# Altium schematic sheet [10]-MODEM_CONNECTORS.SchDoc([10]-MODEM_CONNECTORS)
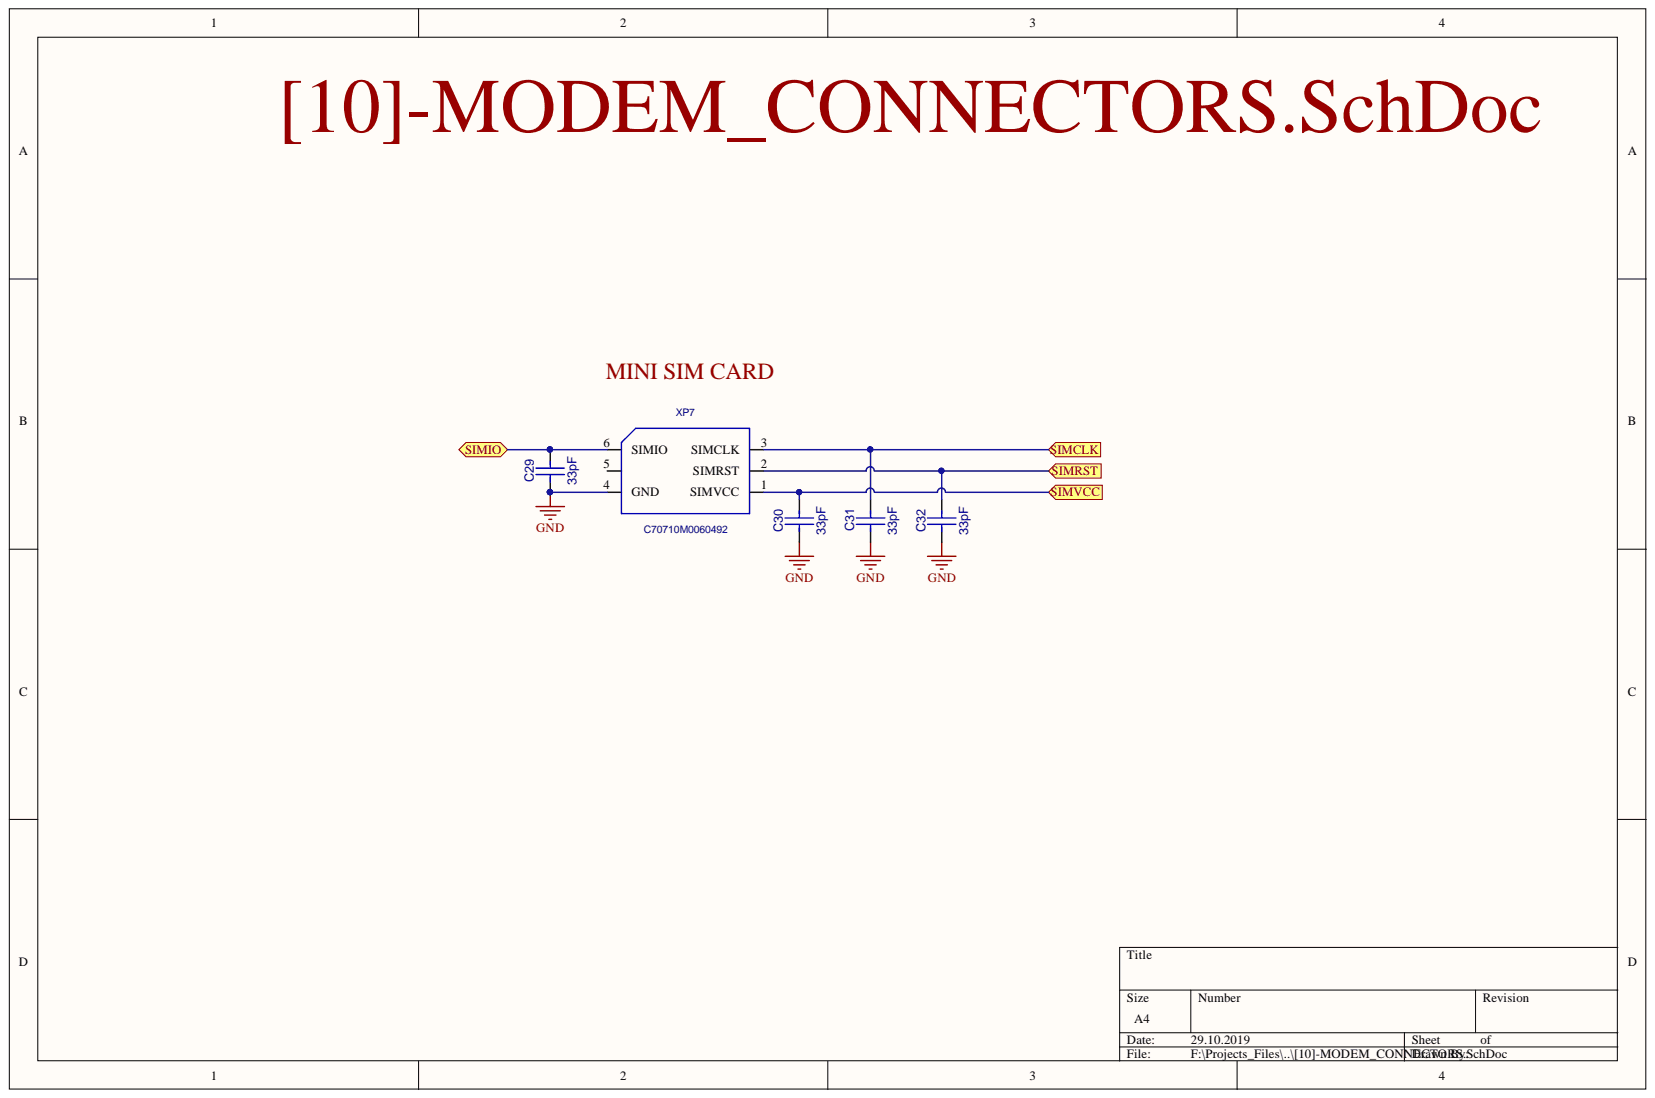
[diagram p0: [10]-MODEM_CONNECTORS.SchDoc]

## Parts
C29  33pF  [CC0603]
C30  33pF  [CC0603]
C31  33pF  [CC0603]
C32  33pF  [CC0603]
XP7  C70710M0060492  [SCVW06A25020]

## Ports
SIMCLK, SIMIO, SIMRST, SIMVCC

## Nets
GND: C29.1 C30.1 C31.1 C32.1 XP7.4(GND)
SIMCLK: C31.2 XP7.3(SIMCLK) ; port SIMCLK
SIMIO: C29.2 XP7.6(SIMIO) ; port SIMIO
SIMRST: C32.2 XP7.2(SIMRST) ; port SIMRST
SIMVCC: C30.2 XP7.1(SIMVCC) ; port SIMVCC

Not connected: XP7 5

## Library data of the parts
C29-C32 = CC0603: Value: 33pF; Description: CC0603; Component Kind: Standard; Library Name: gprs_modem_2.SchLib
XP7 = SCV-W06A25-020: Description: SCV-W06A25-020; Component Kind: Standard; Library Name: gprs_modem_2.SchLib
